# Altium schematic sheet RmtCtrl.SchDoc(RmtCtrl)
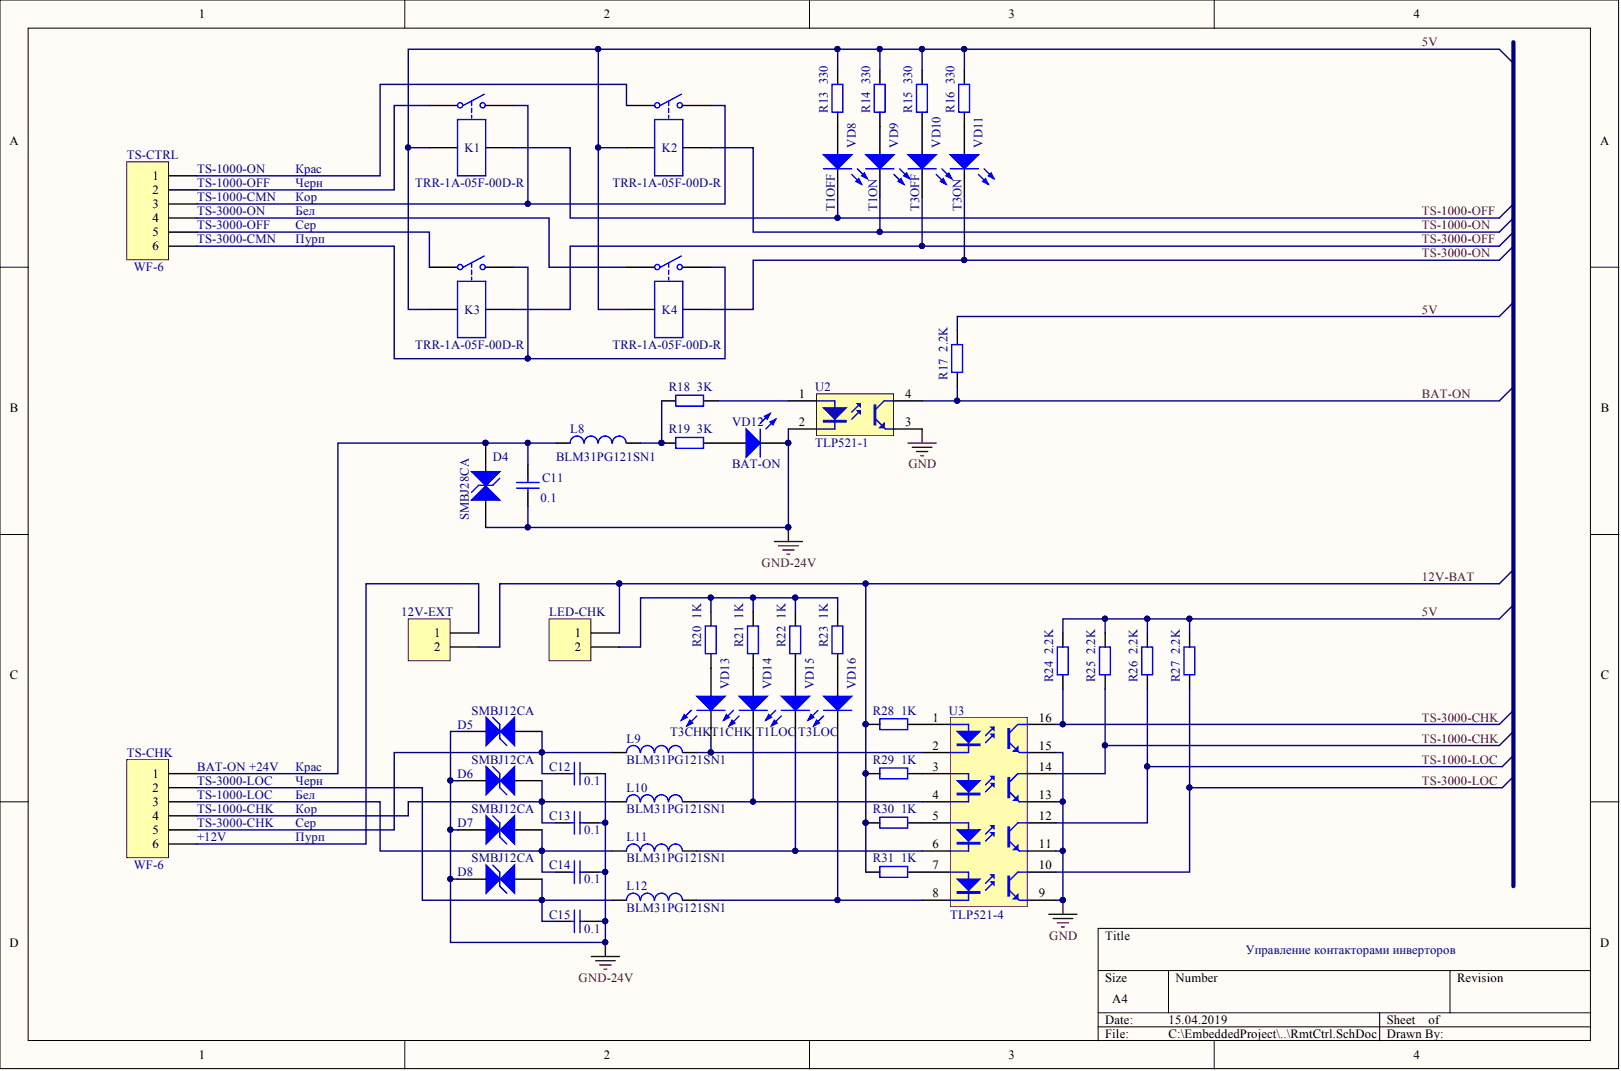
[diagram p0: RmtCtrl.SchDoc]

## Parts
12V-EXT  JP2  [HDR1X2]  (value not drawn)
C11  value 0.1  [C1206]  (hidden comment: Cap)
C12  value 0.1  [C1206]  (hidden comment: Cap)
C13  value 0.1  [C1206]  (hidden comment: Cap)
C14  value 0.1  [C1206]  (hidden comment: Cap)
C15  value 0.1  [C1206]  (hidden comment: Cap)
D4  SMBJ28CA  [DO-214AA]
D5  SMBJ12CA  [DO-214AA]
D6  SMBJ12CA  [DO-214AA]
D7  SMBJ12CA  [DO-214AA]
D8  SMBJ12CA  [DO-214AA]
K1  value TRR-1A-05F-00D-R  [TRR-1A-05F-00D-R]  (hidden comment: Single-Pole Single-Throw Relay)
K2  value TRR-1A-05F-00D-R  [TRR-1A-05F-00D-R]  (hidden comment: Single-Pole Single-Throw Relay)
K3  value TRR-1A-05F-00D-R  [TRR-1A-05F-00D-R]  (hidden comment: Single-Pole Single-Throw Relay)
K4  value TRR-1A-05F-00D-R  [TRR-1A-05F-00D-R]  (hidden comment: Single-Pole Single-Throw Relay)
L8  value BLM31PG121SN1  [L1206]  (hidden comment: Ferrite Chip Beads)
L9  value BLM31PG121SN1  [L1206]  (hidden comment: Ferrite Chip Beads)
L10  value BLM31PG121SN1  [L1206]  (hidden comment: Ferrite Chip Beads)
L11  value BLM31PG121SN1  [L1206]  (hidden comment: Ferrite Chip Beads)
L12  value BLM31PG121SN1  [L1206]  (hidden comment: Ferrite Chip Beads)
LED-CHK  JP2  [HDR1X2]  (value not drawn)
R13  value 330  [1206]  (hidden comment: Res2)
R14  value 330  [1206]  (hidden comment: Res2)
R15  value 330  [1206]  (hidden comment: Res2)
R16  value 330  [1206]  (hidden comment: Res2)
R17  value 2.2K  [1206]  (hidden comment: Res2)
R18  value 3K  [1206]  (hidden comment: Res2)
R19  value 3K  [1206]  (hidden comment: Res2)
R20  value 1K  [1206]  (hidden comment: Res2)
R21  value 1K  [1206]  (hidden comment: Res2)
R22  value 1K  [1206]  (hidden comment: Res2)
R23  value 1K  [1206]  (hidden comment: Res2)
R24  value 2.2K  [1206]  (hidden comment: Res2)
R25  value 2.2K  [1206]  (hidden comment: Res2)
R26  value 2.2K  [1206]  (hidden comment: Res2)
R27  value 2.2K  [1206]  (hidden comment: Res2)
R28  value 1K  [1206]  (hidden comment: Res2)
R29  value 1K  [1206]  (hidden comment: Res2)
R30  value 1K  [1206]  (hidden comment: Res2)
R31  value 1K  [1206]  (hidden comment: Res2)
TS-CHK  value WF-6  [WF-6]  (hidden comment: MHDR1X6)
TS-CTRL  value WF-6  [WF-6]  (hidden comment: MHDR1X6)
U2  TLP521-1  [DIP-4 - OPTO]
U3  TLP521-4  [DIP-16 - OPTO]
VD8  T1OFF  [LED1206 - RED]
VD9  T1ON  [LED1206 - RED]
VD10  T3OFF  [LED1206 - RED]
VD11  T3ON  [LED1206 - RED]
VD12  BAT-ON  [LED1206 - GREEN]
VD13  T3CHK  [LED1206 - YELOW]
VD14  T1CHK  [LED1206 - YELOW]
VD15  T1LOC  [LED1206 - RED]
VD16  T3LOC  [LED1206 - RED]

## Nets
GND: U2.3 U3.9 U3.11 U3.13 U3.15
GND-24V: C11.2 C12.2 C13.2 C14.2 C15.2 D4.1 D5.2 D6.2 D7.2 D8.2 U2.2 VD12.2
5V: K1.3 K2.3 K3.3 K4.3 R13.1 R14.1 R15.1 R16.1 R17.1 R24.1 R25.1 R26.1 R27.1
12V-BAT: 12V-EXT.2 LED-CHK.1 R28.1 R29.1 R30.1 R31.1
BAT-ON: R17.2 U2.4
TS-1000-CHK: R25.2 U3.14
TS-1000-LOC: R26.2 U3.12
TS-1000-OFF: K1.5 VD8.2
TS-1000-ON: K2.5 VD9.2
TS-3000-CHK: R24.2 U3.16
TS-3000-LOC: R27.2 U3.10
TS-3000-OFF: K3.5 VD10.2
TS-3000-ON: K4.5 VD11.2
Net12V-EXT_1: 12V-EXT.1 TS-CHK.6
NetC11_1: C11.1 D4.2 L8.1 TS-CHK.1
NetC12_1: C12.1 D5.1 L9.1 TS-CHK.5
NetC13_1: C13.1 D6.1 L10.1 TS-CHK.4
NetC14_1: C14.1 D7.1 L11.1 TS-CHK.3
NetC15_1: C15.1 D8.1 L12.1 TS-CHK.2
NetK1_1: K1.1 TS-CTRL.2
NetK1_7: K1.7 K2.7 TS-CTRL.3
NetK2_1: K2.1 TS-CTRL.1
NetK3_1: K3.1 TS-CTRL.5
NetK3_7: K3.7 K4.7 TS-CTRL.6
NetK4_1: K4.1 TS-CTRL.4
NetL8_2: L8.2 R18.2 R19.2
NetL9_2: L9.2 U3.2 VD13.2
NetL10_2: L10.2 U3.4 VD14.2
NetL11_2: L11.2 U3.6 VD15.2
NetL12_2: L12.2 U3.8 VD16.2
NetLED-CHK_2: LED-CHK.2 R20.2 R21.2 R22.2 R23.2
NetR13_2: R13.2 VD8.1
NetR14_2: R14.2 VD9.1
NetR15_2: R15.2 VD10.1
NetR16_2: R16.2 VD11.1
NetR18_1: R18.1 U2.1
NetR19_1: R19.1 VD12.1
NetR20_1: R20.1 VD13.1
NetR21_1: R21.1 VD14.1
NetR22_1: R22.1 VD15.1
NetR23_1: R23.1 VD16.1
NetR28_2: R28.2 U3.1
NetR29_2: R29.2 U3.3
NetR30_2: R30.2 U3.5
NetR31_2: R31.2 U3.7
N00681:

## Library data of the parts
12V-EXT LED-CHK = Header 2: Header, 2-Pin; value: Jumper; Component Kind: Standard; LatestRevisionDate: 17-Jul-2002; LatestRevisionNote: Re-released for DXP Platform.; Library Name: Miscellaneous Connectors.IntLib; Signal Integrity: Connector; Publisher: Altium Limited
C11-C15 = Cap: Capacitor; Component Kind: Standard; LatestRevisionDate: 17-Jul-2002; LatestRevisionNote: Re-released for DXP Platform.; Library Name: Miscellaneous Devices.IntLib; PackageReference: RAD-0.3; Published: 8-Jun-2000; Signal Integrity: Cap; Simulation: CAP; Publisher: Altium Limited
D4 = SMBJ28CA: Voltage suppressor; Component Kind: Standard; Library Name: Schlib1.SchLib; Value: SMBJ28CA
D5-D8 = SMBJ12CA: Voltage suppressor; Component Kind: Standard; Library Name: Schlib1.SchLib; Value: SMBJ12CA
K1-K4 = TRR-1A-05F-00D-R: Component Kind: Standard; Description: Single-Pole Single-Throw Relay; LatestRevisionDate: 17-Jul-2002; LatestRevisionNote: Re-released for DXP Platform.; Library Name: Schlib1.SchLib; PackageReference: MODULE4; Published: 24-Mar-1999; Simulation: SPSTRELAY; Publisher: Altium Limited
L8-L12 = Inductor: Code_IEC: 1005; Code_JEITA: 0402; Component Kind: Standard; Description: Ferrite Chip Beads; LatestRevisionDate: 29-May-2009; LatestRevisionNote: IPC-7351 Footprint Added.; Library Name: Miscellaneous Devices.IntLib; PackageDescription: Chip Inductor; PackageReference: 0402-A; Published: 8-Jun-2000; Signal Integrity: Inductor; Simulation: INDUCTOR; Publisher: Altium Limited
R13-R31 = Res2: Resistor; Component Kind: Standard; LatestRevisionDate: 17-Jul-2002; LatestRevisionNote: Re-released for DXP Platform.; Library Name: Miscellaneous Devices.IntLib; PackageReference: AXIAL-0.4; Published: 8-Jun-2000; Signal Integrity: Res; Simulation: RESISTOR; Publisher: Altium Limited
TS-CHK TS-CTRL = MHDR1X6: Header, 6-Pin; Component Kind: Standard; LatestRevisionDate: 17-Jul-2002; LatestRevisionNote: Re-released for DXP Platform.; Library Name: Miscellaneous Connectors.IntLib; Signal Integrity: Connector; Publisher: Altium Limited
U2 = TLP521-1: 1 consist of a photo−transistor; Component Kind: Standard; DatasheetVersion: Nov-2003; LatestRevisionDate: 04-Mar-2005; LatestRevisionNote: Sch pins 3 & 4 Swapped.; Library Name: Schlib1.SchLib; PackageReference: DIP-4; Published: 24-Mar-1999; Value: TLP521-1; Publisher: Altium Limited
U3 = TLP521-4: 4 consist of a photo−transistor; Component Kind: Standard; DatasheetVersion: Nov-2003; LatestRevisionDate: 04-Mar-2005; LatestRevisionNote: Sch pins 3 & 4 Swapped.; Library Name: Schlib1.SchLib; PackageReference: DIP-4; Published: 24-Mar-1999; value: TLP521-4; Publisher: Altium Limited
VD8-VD11 VD15 VD16 = LED0: SMD LED; value: RED; Component Kind: Standard; LatestRevisionDate: 08-Jul-2005; LatestRevisionNote: Mech Layer 1 removed.; Library Name: Miscellaneous Devices.IntLib; PackageReference: LED-0; Published: 8-Jun-2000; Simulation: LED0; Publisher: Altium Limited
VD12 = LED0: SMD LED; Value: GREEN; Component Kind: Standard; LatestRevisionDate: 08-Jul-2005; LatestRevisionNote: Mech Layer 1 removed.; Library Name: Schlib1.SchLib; PackageDescription: LED; 2 Leads; PackageReference: LED-0; Published: 8-Jun-2000; Simulation: LED0; Publisher: Altium Limited
VD13 VD14 = LED0: SMD LED; value: ORANGE; Component Kind: Standard; LatestRevisionDate: 08-Jul-2005; LatestRevisionNote: Mech Layer 1 removed.; Library Name: Miscellaneous Devices.IntLib; PackageReference: LED-0; Published: 8-Jun-2000; Simulation: LED0; Publisher: Altium Limited
